# Altium schematic sheet MINIECO-SERIALI.SchDoc(MINIECO-SERIALI)
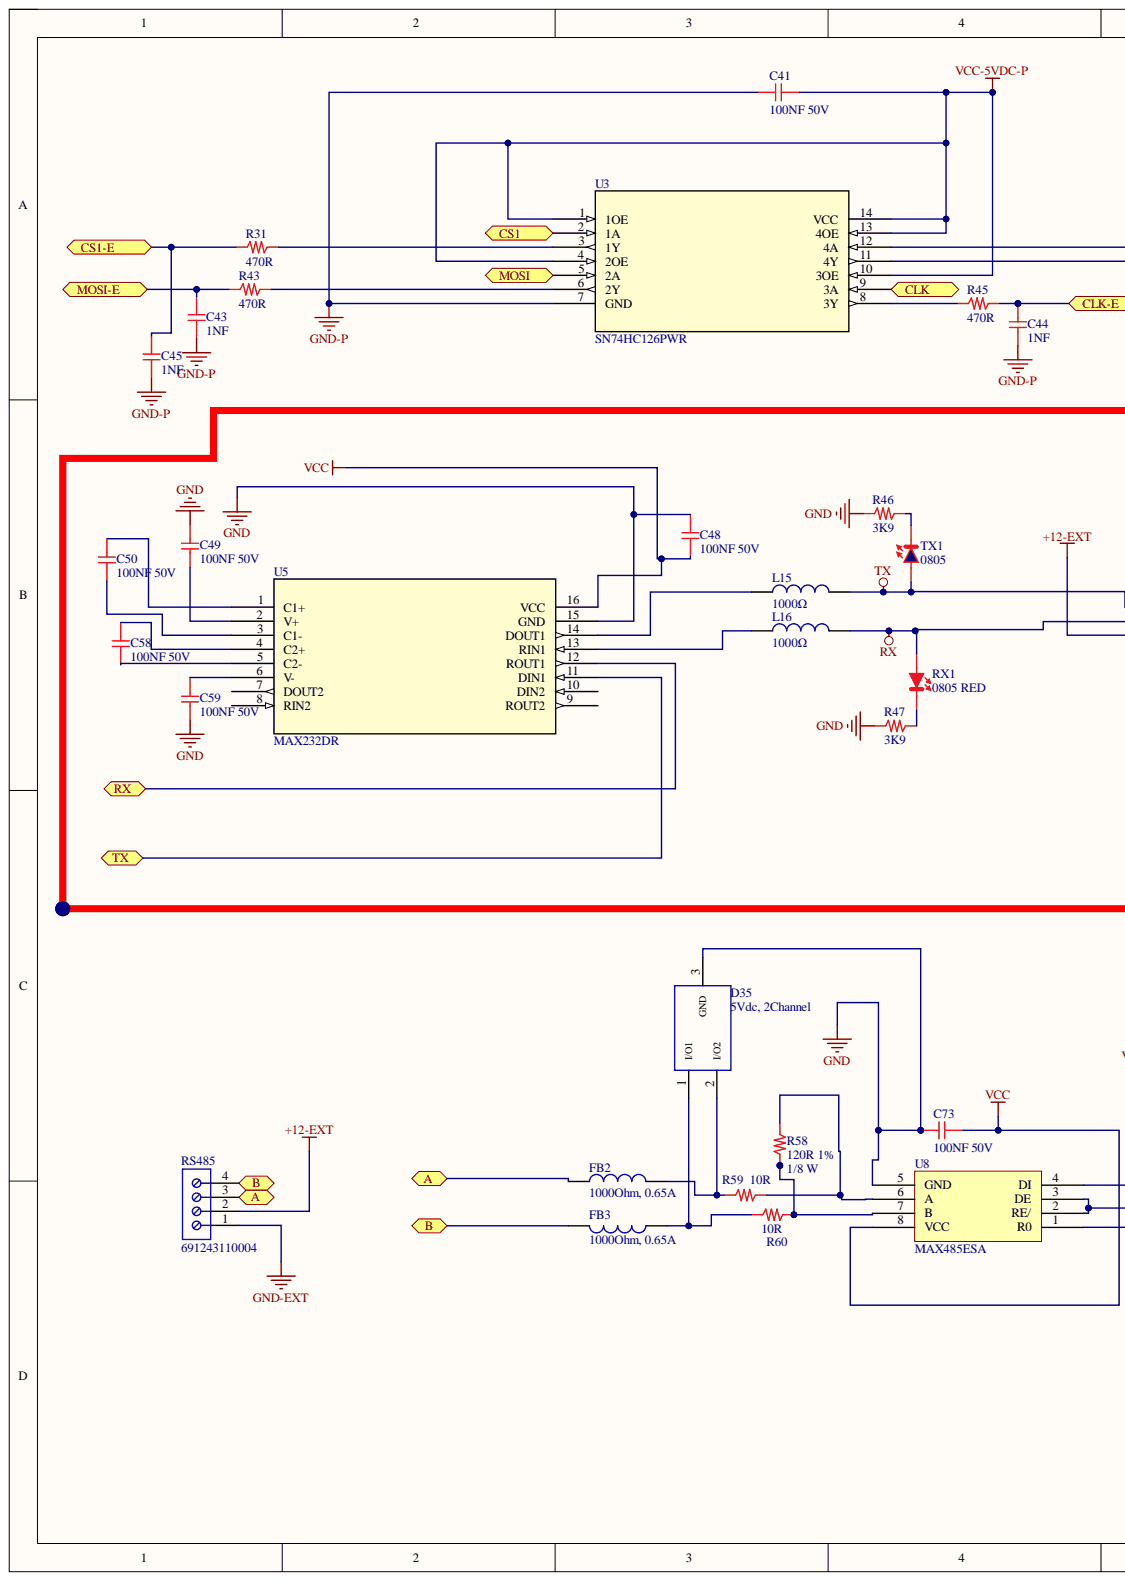
[diagram p0: MINIECO-SERIALI.SchDoc, left]
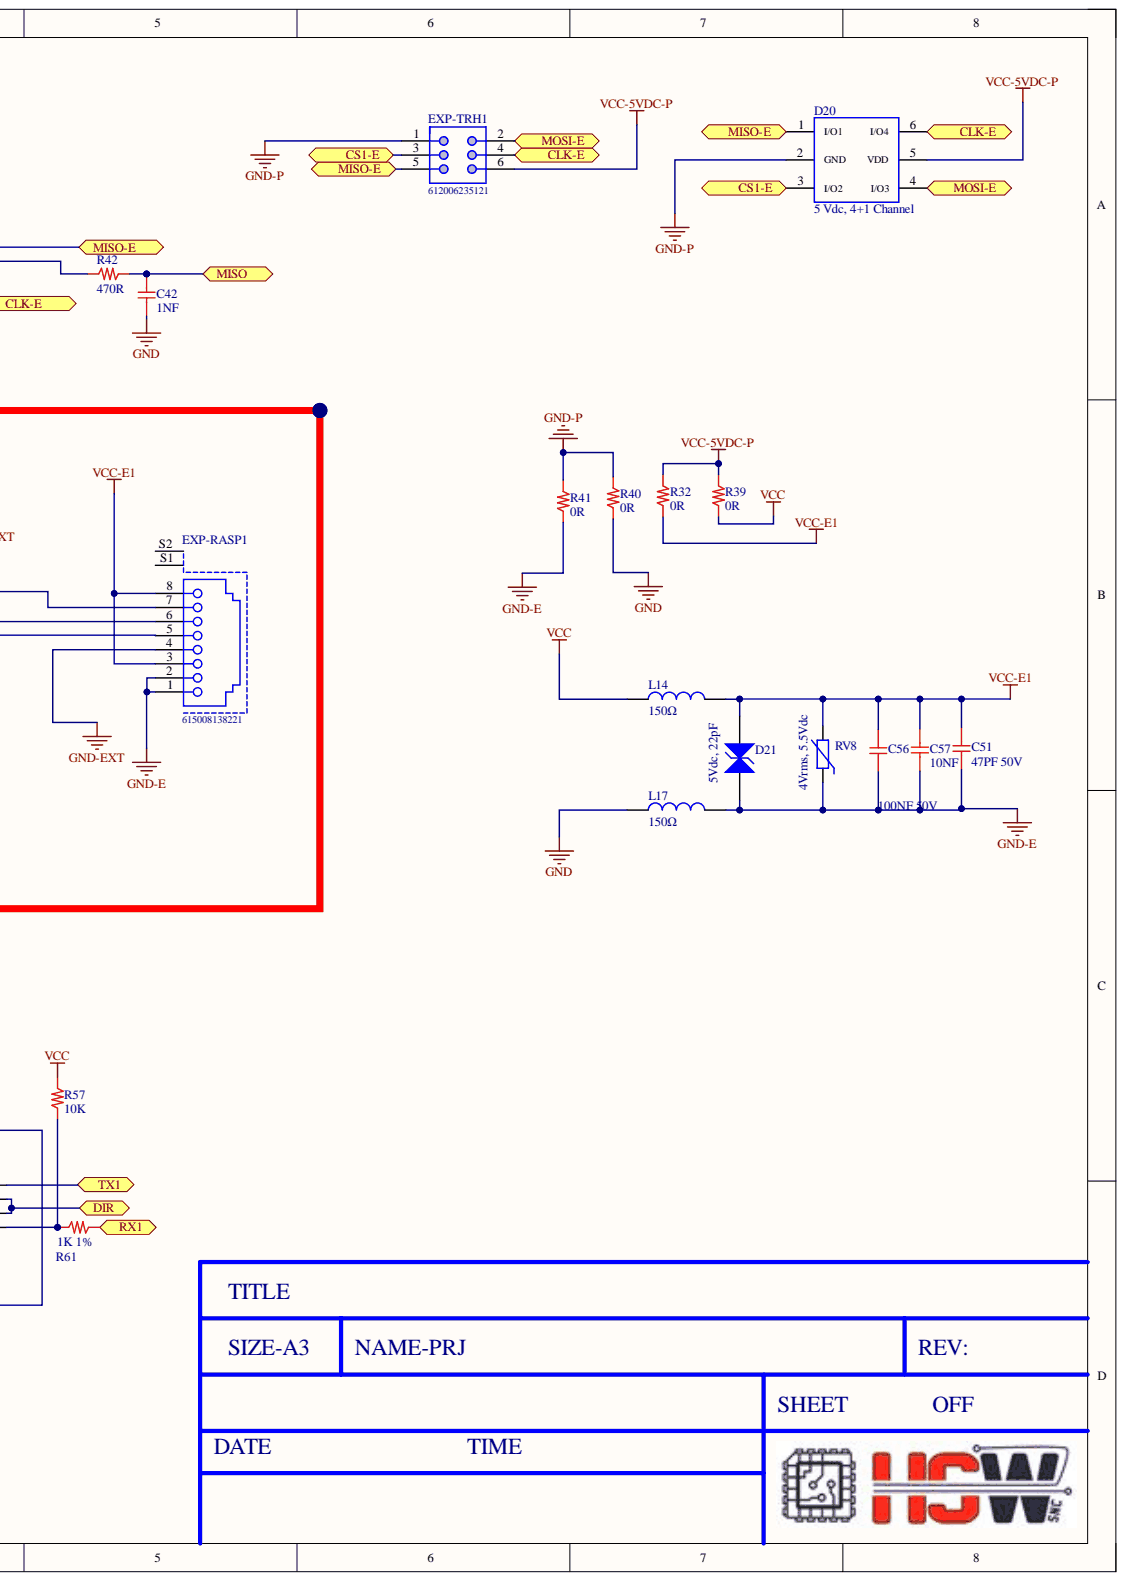
[diagram p1: MINIECO-SERIALI.SchDoc, right]

## Parts
C41  100NF 50V  [0603_c]
C42  1NF  [0603_c]
C43  1NF  [0603_c]
C44  1NF  [0603_c]
C45  1NF  [0603_c]
C48  100NF 50V  [0603_c]
C49  100NF 50V  [0603_c]
C50  100NF 50V  [0603_c]
C51  47PF 50V  [0603_c]
C56  100NF 50V  [0603_c]
C57  10NF  [0603_c]
C58  100NF 50V  [0603_c]
C59  100NF 50V  [0603_c]
C73  100NF 50V  [0603_c]
D20  5 Vdc, 4+1 Channel  Channel: 4+1  [WE-TVS_High Speed_SOT23-6L]
D21  5Vdc, 22pF  Capacitance: 22pF  [WE-VE_0603]
D35  5Vdc, 2Channel  [WE-TVS_Standard_SOT23-3L]
EXP-RASP1  615008138221  [615008138221]
EXP-TRH1  612006235121  [612006235121]
FB2  1000Ohm, 0.65A  Rated Current: 0.65A  [WE-CBF_0603_Wide Band]
FB3  1000Ohm, 0.65A  Rated Current: 0.65A  [WE-CBF_0603_Wide Band]
L14  150Ω  [WE-CBF_0805_High Speed]
L15  1000Ω  [WE-CBF_0805_Wide Band]
L16  1000Ω  [WE-CBF_0805_Wide Band]
L17  150Ω  [WE-CBF_0805_High Speed]
R31  470R  [0603_R]
R32  0R  [0603_R]
R39  0R  [0603_R]
R40  0R  [0603_R]
R41  0R  [0603_R]
R42  470R  [0603_R]
R43  470R  [0603_R]
R45  470R  [0603_R]
R46  3K9  [0603_R]
R47  3K9  [0603_R]
R57  10K  [0603_R]
R58  120R 1%  Potenza: 1/8 W  [0805_R]
R59  10R  [0805_R]
R60  10R  [0805_R]
R61  1K 1%  [0402_R]
RS485  691243110004  [691243110004]
RV8  4Vrms, 5.5Vdc  DC Operating Voltage: 5.5Vdc  [WE-VS_0603]
RX1  0805 RED  [0805_Red]
TX1  0805  [0805-BLU]
U3  SN74HC126PWR  [TSSOP14_4P50X5P10_ONS-M]
U5  MAX232DR  [D16]
U8  MAX485ESA  [SO-8]

## Ports
A, B, CLK, CLK-E, CS1, CS1-E, DIR, MISO, MISO-E, MOSI, MOSI-E, RX, RX1, TX, TX1

## Nets
+12-EXT: EXP-RASP1.5 RS485.2
GND: C42.1 C48.1 C49.1 C59.2 C73.1 D35.3 L17.1 R40.1 R46.1 R47.1 U5.15(GND) U8.5(GND)
GND-E: C51.2 C56.1 C57.2 D21.1 EXP-RASP1.1 EXP-RASP1.2 L17.2 R41.1 RV8.1
GND-EXT: EXP-RASP1.4 RS485.1
GND-P: C41.2 C43.1 C44.1 C45.1 D20.2(GND) EXP-TRH1.1 R40.2 R41.2 U3.7(GND)
RX: EXP-RASP1.6 L16.2 RX1.A
TX: EXP-RASP1.7 L15.2 TX1.A
VCC: C48.2 C73.2 L14.1 R39.1 R57.1 U5.16(VCC) U8.8(VCC)
VCC-5VDC-P: C41.1 D20.5(VDD) EXP-TRH1.6 R32.2 R39.2 U3.1(1OE) U3.4(2OE) U3.10(3OE) U3.13(4OE) U3.14(VCC)
VCC-E1: C51.1 C56.2 C57.1 D21.2 EXP-RASP1.3 EXP-RASP1.8 L14.2 R32.1 RV8.2
A: FB2.1 RS485.3 ; port A
B: FB3.2 RS485.4 ; port B
CLK: U3.9(3A) ; port CLK
CLK-E: C44.2 D20.6(I/O4) EXP-TRH1.4 R45.1 ; port CLK-E
CS1: U3.2(1A) ; port CS1
CS1-E: C45.2 D20.3(I/O2) EXP-TRH1.3 R31.2 ; port CS1-E
DIR: U8.2(RE/) U8.3(DE) ; port DIR
MISO: C42.2 R42.1 ; port MISO
MISO-E: D20.1(I/O1) EXP-TRH1.5 U3.12(4A) ; port MISO-E
MOSI: U3.5(2A) ; port MOSI
MOSI-E: C43.2 D20.4(I/O3) EXP-TRH1.2 R43.2 ; port MOSI-E
RX1: R61.2 ; port RX1
TX1: U8.4(DI) ; port TX1
NetC49_2: C49.2 U5.2(V+)
NetC50_1: C50.1 U5.1(C1+)
NetC50_2: C50.2 U5.3(C1-)
NetC58_1: C58.1 U5.4(C2+)
NetC58_2: C58.2 U5.5(C2-)
NetC59_1: C59.1 U5.6(V-)
NetD35_1: D35.1 FB3.1 R60.1
NetD35_2: D35.2 FB2.2 R59.1
NetL15_1: L15.1 U5.14(DOUT1)
NetL16_1: L16.1 U5.13(RIN1)
NetR31_1: R31.1 U3.3(1Y)
NetR42_2: R42.2 U3.11(4Y)
NetR43_1: R43.1 U3.6(2Y)
NetR45_2: R45.2 U3.8(3Y)
NetR46_2: R46.2 TX1.K
NetR47_2: R47.2 RX1.K
NetR57_2: R57.2 R61.1 U8.1(R0)
NetR58_1: R58.1 R59.2 U8.6(A)
NetR58_2: R58.2 R60.2 U8.7(B)
NetU5_11: U5.11(DIN1) ; port TX
NetU5_12: U5.12(ROUT1) ; port RX

Not connected: EXP-RASP1 S1 S2; U5 7(DOUT2) 8(RIN2) 9(ROUT2) 10(DIN2)

## Library data of the parts
C41 C48-C50 C56 C58 C59 C73 = 100NF 50V: Cap X7R 100nF 50V Flex Terminal; Cod. Interno: COCS50665050104; Cod. Produttore: CL10B104KB8SFNC; Produttore: Samsung; Cod. DigiKey: 1276-1936-2-ND; Component Kind: Standard; Library Name: CapSMD0603.SchLib
C42-C45 = 1NF 50V: Cap X7R 1nF 50V; Cod. Interno: COCS 50665050102; Component Kind: Standard; Library Name: CapSMD0603.SchLib
C51 = 33PF 50V: Cer COG 33PF 5% 50V; Cod. Interno: COCS50665016330; Cod. Produttore: 06035A330JAT2A; Produttore: AVX; Component Kind: Standard; Library Name: CapSMD0603.SchLib
C57 = 10NF 50V: Cap X7R 10nF 50V; Cod. Interno: COCS 556655000103; Cod. Produttore: CL05B103KB5NNNC; Produttore: Samsung; Cod. DigiKey: 1276-1028-2-ND; Component Kind: Standard; Library Name: CapSMD0603.SchLib
D20 = 824015: TVS Diode - High Speed Series WE-TVS, SOT23-6L, 5 Vdc, 4+1 Channel; Channel Input Capacitance: 2 pF; DC Operating Voltage: 5 V; Reverse Breakdown Voltage min.: 6.1 V; Reverse Channel Leakage Current: 2 uA; Reverse Peak Pulse Current: 12 A; Component Kind: Standard; ComponentLink1Description: Manufacturer; ComponentLink1URL: http://www.we-online.de; ComponentLink2Description: Datasheet; ComponentLink2URL: https://katalog.we-online.de/pbs/datasheet/824015.pdf; Library Name: WE-TVS_High Speed Series.SchLib; Mounting Technology: SMT; Operating Temperature: -40 to 125 degC; Packaging: Tape and Reel; Part Number: 824015; Published Date: November 2018; Size: SOT23-6L; Publisher: Wurth Elektronik
D21 = 82356050220: WE-VE ESD Suppressor, size 0603, 5Vdc, 22pF; Clamp Voltage: 55V; ESD Voltage air discharge: 15kV; Leakage Current: 1uA; Component Kind: Standard; ComponentLink1Description: Manufacturer; ComponentLink1URL: http://www.we-online.de; ComponentLink2Description: Datasheet; ComponentLink2URL: https://katalog.we-online.com/pbs/datasheet/82356050220.pdf; Library Name: WE-VE_0603.SchLib; Mounting Technology: SMT; Operating Temperature: -40 to +85degC; Packaging: Tape and Reel; Part Number: 82356050220; Published Date: June 2019; Size: 0603; Working Voltage: 5Vdc; Publisher: Wurth Elektronik
D35 = 824022: WE-TVS TVS Diode - Standard Series, SOT23-3L, 5Vdc, 2 Channel; Channel: 2; Channel Input Capacitance: 12pF; DC Operating Voltage: 5V; Reverse Breakdown Voltage min.: 6.1V; Reverse Channel Leakage Current: 2.5uA; Reverse Peak Pulse Current: 8A; Component Kind: Standard; ComponentLink1Description: Manufacturer; ComponentLink1URL: http://www.we-online.de; ComponentLink2Description: Datasheet; ComponentLink2URL: https://katalog.we-online.com/pbs/datasheet/824022.pdf; Library Name: WE-TVS.SchLib; Mounting Technology: SMT; Operating Temperature: -55 to +125degC; Packaging: Tape and Reel; Part Number: 824022; Published Date: June 2019; Size: SOT23-3L; Publisher: Wurth Elektronik
EXP-RASP1 = 615008138221: Modular Jack WR-MJ, Front Post, Shielded, Vertical Entry, THT, 8P8C; Compliance: Lead free / RoHS; Contact Resistance: 20 mOhm; Port: 1x1; Rated Current: 1.5 A; Withstanding Voltage: 1000 VAC; Working Voltage: 120 VAC; Component Kind: Standard; ComponentLink1Description: Manufacturer; ComponentLink1URL: http://www.we-online.de; ComponentLink2Description: Datasheet; ComponentLink2URL: https://katalog.we-online.com/em/datasheet/615008138221.pdf; Entrance: Vertical; Library Name: RJ45-8.SchLib; Mounting Technology: THT; Operating Temperature: -40 to 85 degC; Packaging: Tray; Part Number: 615008138221; Pin Number: 8P8C; Published Date: July 2018; Publisher: Wurth Elektronik
EXP-TRH1 = 612006235121: Male Box Header WR-BHD, THT, Vertical, pitch 2.54 mm, 6 pins; Contact Resistance: 20 mOhm; Pin Number: 6; Pitch: 2.54 mm; Withstanding Voltage: 500 VAC; Working Voltage: 250 VAC; Component Kind: Standard; ComponentLink1Description: Manufacturer; ComponentLink1URL: http://www.we-online.de; ComponentLink2Description: Datasheet; ComponentLink2URL: https://katalog.we-online.de/em/datasheet/6120xx235121.pdf; Gender: Male; Library Name: WR-BHD_Box Headers_2.54mm.SchLib; Mounting Technology: THT; Operating Temperature: -40 to 125 degC; Orientation: Vertical; Packaging: Tray; Part Number: 612006235121; Published Date: August 2018; Publisher: Wurth Elektronik
FB2 FB3 = 74279266: WE-CBF SMT EMI Suppression Ferrite Bead, size 0603, 1000Ohm, 0.65A; DC Resistance max.: 0.6Ohm; Impedance max.: 1350Ohm; Type: Wide Band; Component Kind: Standard; ComponentLink1Description: Manufacturer; ComponentLink1URL: http://www.we-online.de; ComponentLink2Description: Datasheet; ComponentLink2URL: https://katalog.we-online.com/pbs/datasheet/74279266.pdf; Impedance@100 MHz: 1000Ohm; Library Name: WE-CBF_0603.SchLib; Mounting Technology: SMT; Operating Temperature: -55 to +125degC; Packaging: Tape and Reel; Part Number: 74279266; Published Date: March 2019; Size: 0603; Publisher: Wurth Elektronik
L14 L17 = WE-CBF, 74279203: WE-CBF SMD EMI Suppression Ferrite Bead, 0805, 150Ω; Type: High Speed; Component Kind: Standard; ComponentLink1Description: Manufacturer; ComponentLink1URL: http://www.we-online.de; ComponentLink2Description: Datasheet; ComponentLink2URL: http://katalog.we-online.de/pbs/datasheet/74279203.pdf; Library Name: WE-CBF_0805.SchLib; PartNumber: 74279203; PublishedDate: Aug 2016; Size: 0805; Value: 150Ω; Publisher: Wurth Elektronik
L15 L16 = WE-CBF, 74279205: WE-CBF SMD EMI Suppression Ferrite Bead, 0805, 1000Ω; Type: Wide Band; Component Kind: Standard; ComponentLink1Description: Manufacturer; ComponentLink1URL: http://www.we-online.de; ComponentLink2Description: Datasheet; ComponentLink2URL: http://katalog.we-online.de/pbs/datasheet/74279205.pdf; Library Name: WE-CBF_0805.SchLib; PartNumber: 74279205; PublishedDate: Aug 2016; Size: 0805; Value: 1000Ω; Publisher: Wurth Elektronik
R31 R42 R43 R45 = 470R: Res Film Spesso 1/16W 470R 5%; Cod. Interno: RESS4166556B0471??; Cod. Produttore: ??; Component Kind: Standard; Library Name: ResSMD0603.SchLib; Produttore: ??
R32 R39-R41 = 0R: Res Film Spesso 1/16W 0R 5%; Cod. Interno: RESS 4166556B0000; Component Kind: Standard; Library Name: ResSMD0603.SchLib
R46 R47 = 3K9: Res Film Spesso 1/16W 3K9 5%; Cod. Interno: RESS4166556B0392; Cod. Produttore: CRCW06033K90JNEA; Produttore: VISHAY; Component Kind: Standard; Library Name: ResSMD0603.SchLib
R57 = 10K: Res Film Spesso 1/16W 10K 5%; Cod. Interno: RESS4166556B0103??; Cod. Produttore: ??; Component Kind: Standard; Library Name: ResSMD0603.SchLib; Produttore: ??
R58 = 120R 1%: Res Film Spesso 1/8W 125mW 120R 1%; Cod. Interno: RESS 4170355B0101; Cod. Produttore: CR0805-FX-1000ELF; Cod. Mouser: 652-CR0805FX-1000ELF; Component Kind: Standard; Library Name: ResSMD0805.SchLib
R59 R60 = 10R: Res Film Spesso 1/8W 10R 5%; Cod. Interno: RESS4170555B0100; Potenza: 1/8W 5%; Component Kind: Standard; Library Name: ResSMD0805.SchLib
R61 = 1K 1%: Res Film Spesso 1/16W 1K 1%; Cod. Interno: RESS4165360C0103; Cod. Produttore: ??; Component Kind: Standard; Library Name: ResSMD0402.SchLib; Produttore: ??
RS485 = 691243110004: WR-TBL Serie 2431 Horizontal Cable Entry, Rising Cage Clamp, THT, pitch 3.5mm, 4p; Manufacturer: Wurth Elektronik; Modularity: No; PCB/Cable/Panel: PCB; Pin Number: 4; Rated Current [UL]: 10A; Working Voltage [UL]: 300VAC; Application: Rising Cage Clamp; Component Kind: Standard; ComponentLink1Description: Manufacturer; ComponentLink1URL: http://www.we-online.de; ComponentLink2Description: Datasheet; ComponentLink2URL: https://katalog.we-online.de/em/datasheet/691243110004.pdf; Library Name: WR-TBL_Rising Cage Clamp.SchLib; Manufacturer Part Number: 691243110004; Mounting Technology: THT; Operating Temperature: -30 to +120degC; Packaging: Box; Pitch: 3.5mm; Published Date: Feburary 2021; Type: Horizontal
RV8 = 82536040: WE-VS SMD Varistor, size 0603, 4Vrms, 5.5Vdc; Clamping Voltage: 21V; Energy Dissipation: 0.1J; Varistor Voltage: 8V; Withstanding Surge Current: 30A; AC Operating Voltage: 4Vrms; Component Kind: Standard; ComponentLink1Description: Manufacturer; ComponentLink1URL: http://www.we-online.de; ComponentLink2Description: Datasheet; ComponentLink2URL: https://katalog.we-online.com/pbs/datasheet/82536040.pdf; Library Name: WE-VS_0603.SchLib; Mounting Technology: SMT; Operating Temperature: -40 to +85degC; Packaging: Tape and Reel; Part Number: 82536040; Published Date: March 2019; Size: 0603; Publisher: Wurth Elektronik
RX1 = LED_ROSSO_0805: LED Rosso 0805; Cod. Interno: LEDS 2AC080RS7500; Cod. Produttore: 150080RS75000; Luminosità: 150mcd; Produttore: WE; V: 2.0V; Component Kind: Standard; Library Name: DiodiLED.SchLib
TX1 = LED BLU 0805: Cod. Produttore: KPTR-3216QBC-D; Luminosità: 100mcd 20mA; Produttore: kingbright; Vf(typ): 3.3V; Component Kind: Standard; Description: LED BLU 0805; Library Name: DiodiLED.SchLib
U3 = SN74HC126PWR: SNx4HC125 Quadruple Buffers with 3-State Outputs; Manufacturer_Part_Number: SN74HC125DR; Built_By: EMA_UL_Team; C: Copyright (C) 2015 Accelerated Designs. All rights reserved; Vendor: Texas Instruments; Component Kind: Standard; RefDes: RefDes; Type: DEV; Value: Value; Library Name: HSW.SchLib
U5 = MAX232DR: RS232 TRANCIVER; Manufacturer_Part_Number: MAX3232IDR; Built_By: EMA_UL_Team; Vendor: Texas Instruments; Component Kind: Standard; RefDes: RefDes; Type: DEV; Value: Value; Copyright: Copyright (C) 2018 Accelerated Designs. All rights reserved; Library Name: HSW.SchLib
U8 = MAX485ESA: Low-Power, Slew-Rate-Limited  RS-485/RS-422 Transceivers; Component Kind: Standard; Library Name: HSW.SchLib
